# Altium schematic sheet Backplane-Connector.SchDoc(Backplane-Connector)
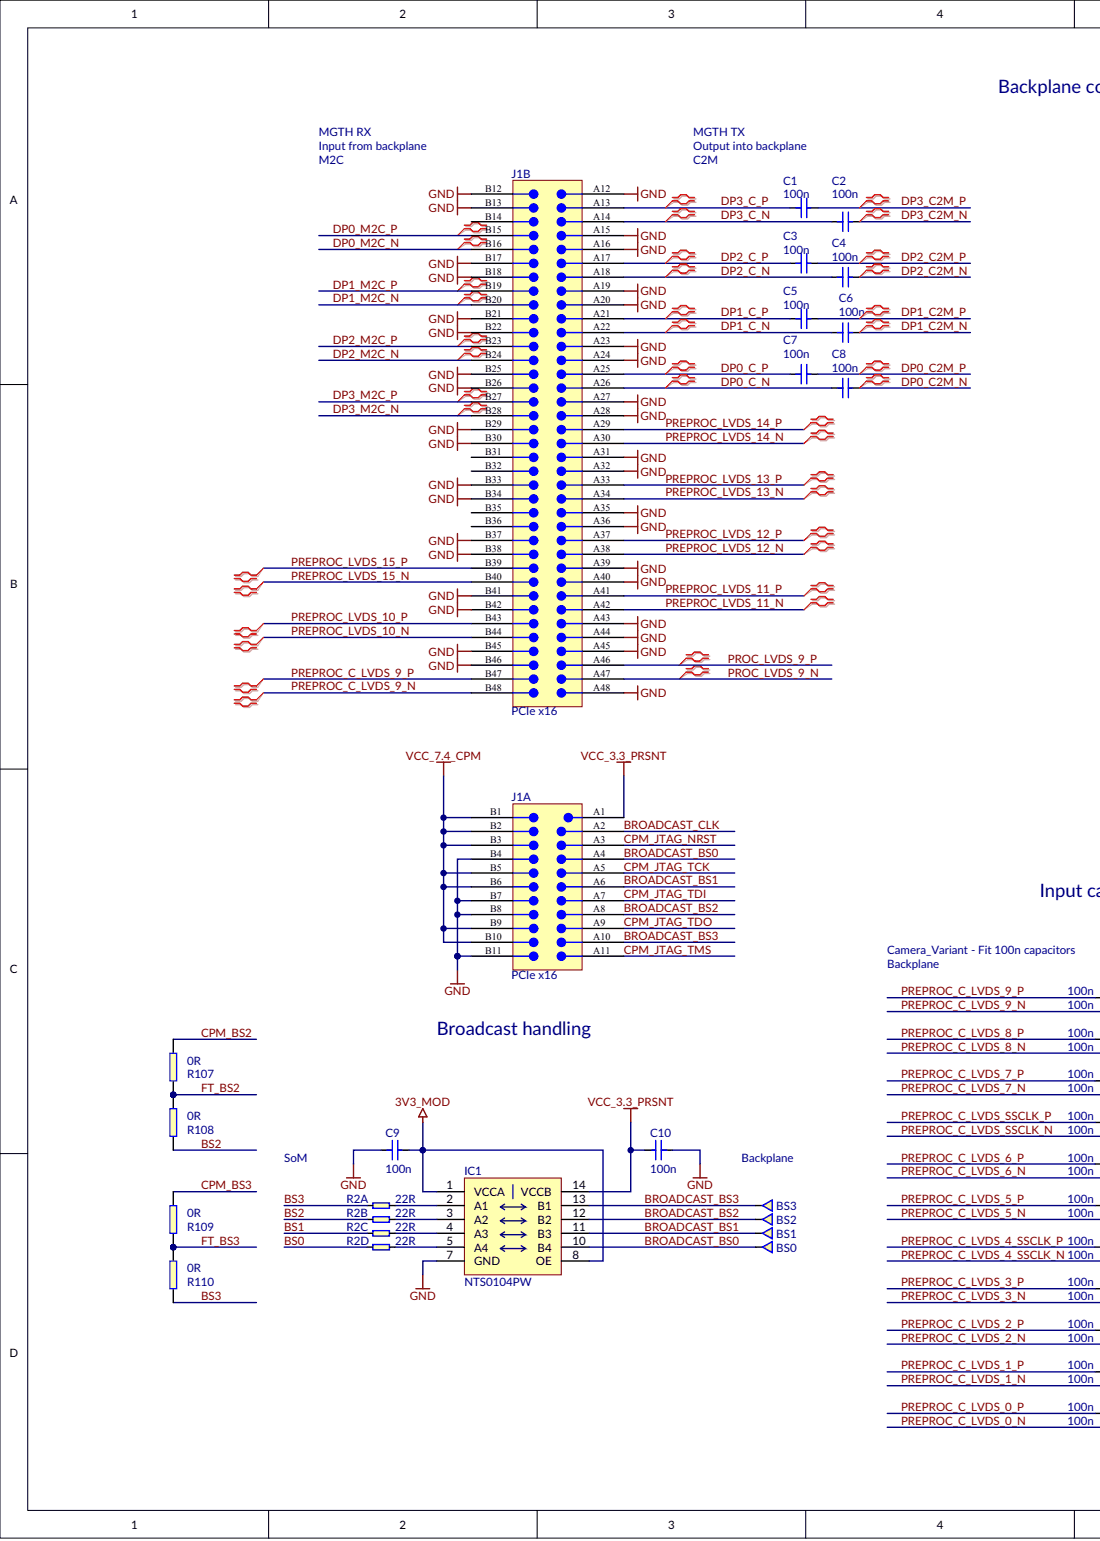
[diagram p0: Backplane-Connector.SchDoc, left]
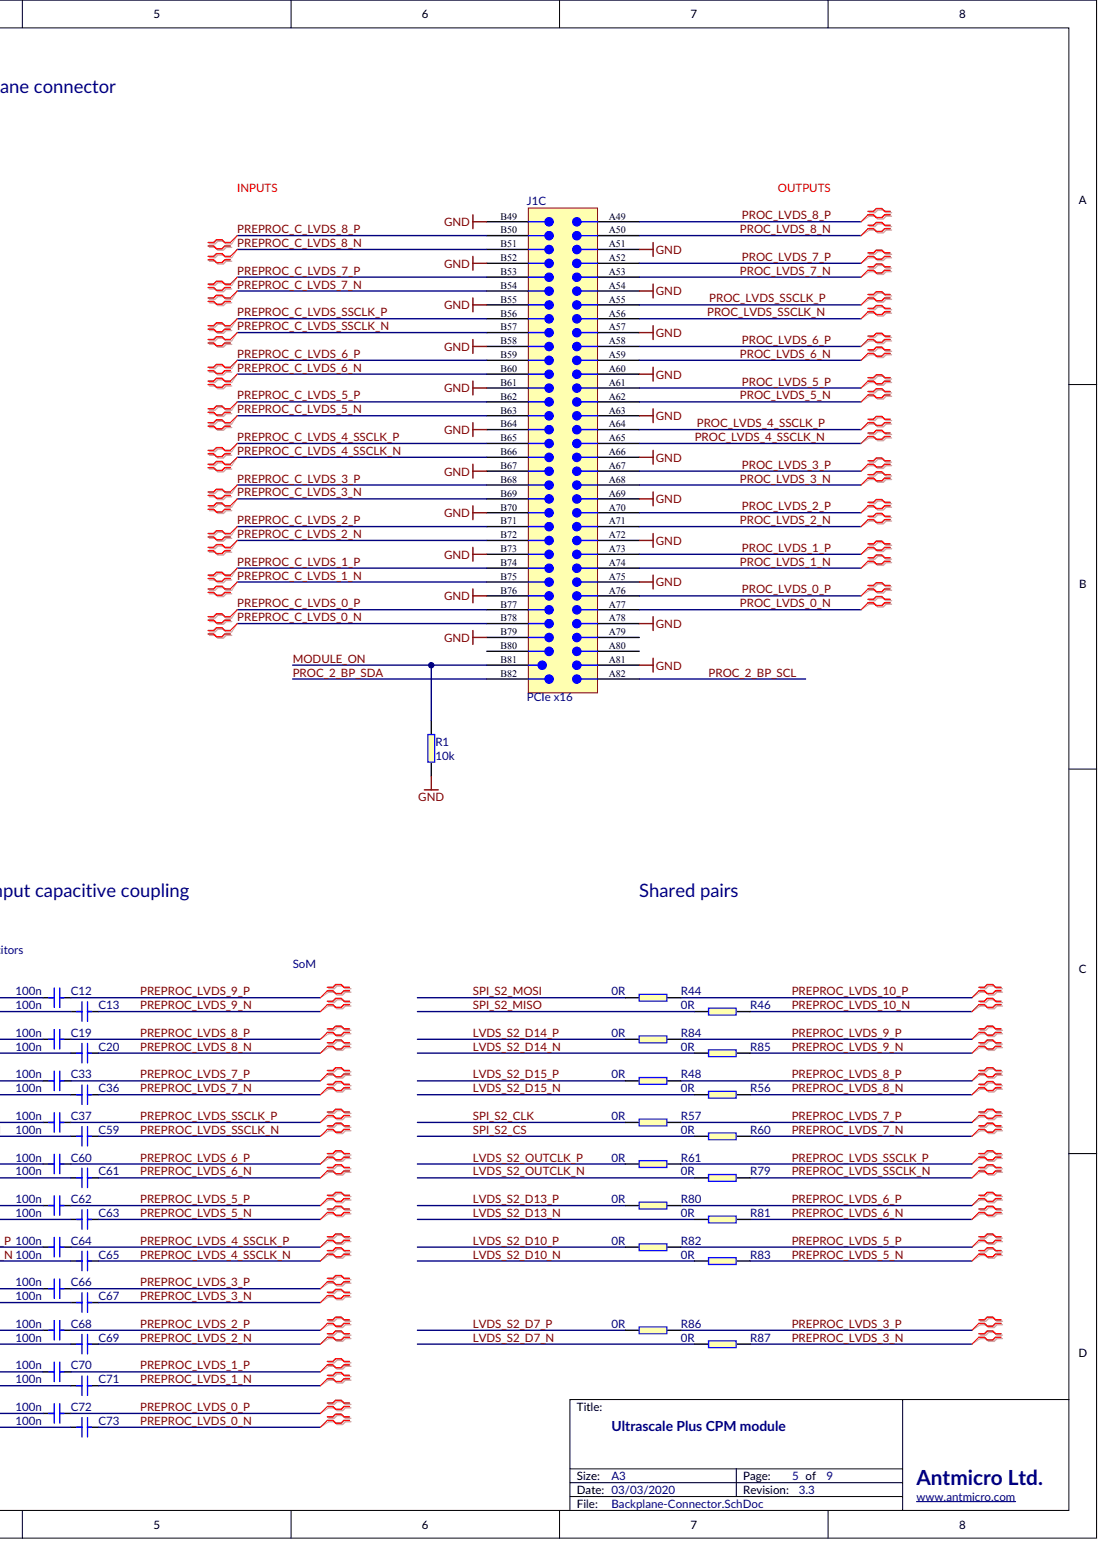
[diagram p1: Backplane-Connector.SchDoc, right]

## Parts
BS0  *  (value not drawn)
BS1  *  (value not drawn)
BS2  *  (value not drawn)
BS3  *  (value not drawn)
C1  100n
C2  100n
C3  100n
C4  100n
C5  100n
C6  100n
C7  100n
C8  100n
C9  100n
C10  100n
C12  100n
C13  100n
C19  100n
C20  100n
C33  100n
C36  100n
C37  100n
C59  100n
C60  100n
C61  100n
C62  100n
C63  100n
C64  100n
C65  100n
C66  100n
C67  100n
C68  100n
C69  100n
C70  100n
C71  100n
C72  100n
C73  100n
IC1  NTS0104PW
J1  PCIe x16  (parts A,B,C)
R1  10k
R2  22R  (parts A,B,C,D)
R44  0R
R46  0R
R48  0R
R56  0R
R57  0R
R60  0R
R61  0R
R79  0R
R80  0R
R81  0R
R82  0R
R83  0R
R84  0R
R85  0R
R86  0R
R87  0R
R107  0R
R108  0R
R109  0R
R110  0R

## Nets
3V3_MOD: C9.2 IC1.1(VCCA) IC1.8(OE)
GND: C9.1 C10.1 IC1.7(GND) J1.A12 J1.A15 J1.A16 J1.A19 J1.A20 J1.A23 J1.A24 J1.A27 J1.A28 J1.A31 J1.A32 J1.A35 J1.A36 J1.A39 J1.A40 J1.A43 J1.A44 J1.A45 J1.A48 J1.A51 J1.A54 J1.A57 J1.A60 J1.A63 J1.A66 J1.A69 J1.A72 J1.A75 J1.A78 J1.A81 J1.B4 J1.B7 J1.B8 J1.B11 J1.B12 J1.B13 J1.B17 J1.B18 J1.B21 J1.B22 J1.B25 J1.B26 J1.B29 J1.B30 J1.B33 J1.B34 J1.B37 J1.B38 J1.B41 J1.B42 J1.B45 J1.B46 J1.B49 J1.B52 J1.B55 J1.B58 J1.B61 J1.B64 J1.B67 J1.B70 J1.B73 J1.B76 J1.B79 R1.1
VCC_3.3_PRSNT: C10.2 IC1.14(VCCB) J1.A1
VCC_7.4_CPM: J1.B1 J1.B2 J1.B3 J1.B5 J1.B6 J1.B9 J1.B10
BROADCAST_BS0: BS0.1 IC1.10(B4) J1.A4
BROADCAST_BS1: BS1.1 IC1.11(B3) J1.A6
BROADCAST_BS2: BS2.1 IC1.12(B2) J1.A8
BROADCAST_BS3: BS3.1 IC1.13(B1) J1.A10
BROADCAST_CLK: J1.A2
BS0: R2.7
BS1: R2.5
BS2: R2.3 R108.1
BS3: R2.1 R110.1
CPM_BS2: R107.2
CPM_BS3: R109.2
CPM_JTAG_NRST: J1.A3
CPM_JTAG_TCK: J1.A5
CPM_JTAG_TDI: J1.A7
CPM_JTAG_TDO: J1.A9
CPM_JTAG_TMS: J1.A11
DP0_C2M_N: C8.2
DP0_C2M_P: C7.2
DP0_C_N: C8.1 J1.A26
DP0_C_P: C7.1 J1.A25
DP0_M2C_N: J1.B16
DP0_M2C_P: J1.B15
DP1_C2M_N: C6.2
DP1_C2M_P: C5.2
DP1_C_N: C6.1 J1.A22
DP1_C_P: C5.1 J1.A21
DP1_M2C_N: J1.B20
DP1_M2C_P: J1.B19
DP2_C2M_N: C4.2
DP2_C2M_P: C3.2
DP2_C_N: C4.1 J1.A18
DP2_C_P: C3.1 J1.A17
DP2_M2C_N: J1.B24
DP2_M2C_P: J1.B23
DP3_C2M_N: C2.2
DP3_C2M_P: C1.2
DP3_C_N: C2.1 J1.A14
DP3_C_P: C1.1 J1.A13
DP3_M2C_N: J1.B28
DP3_M2C_P: J1.B27
FT_BS2: R107.1 R108.2
FT_BS3: R109.1 R110.2
LVDS_S2_D7_N: R87.1
LVDS_S2_D7_P: R86.1
LVDS_S2_D10_N: R83.1
LVDS_S2_D10_P: R82.1
LVDS_S2_D13_N: R81.1
LVDS_S2_D13_P: R80.1
LVDS_S2_D14_N: R85.1
LVDS_S2_D14_P: R84.1
LVDS_S2_D15_N: R56.1
LVDS_S2_D15_P: R48.1
LVDS_S2_OUTCLK_N: R79.1
LVDS_S2_OUTCLK_P: R61.1
MODULE_ON: J1.B81 R1.2
PREPROC_C_LVDS_0_N: C73.1 J1.B78
PREPROC_C_LVDS_0_P: C72.1 J1.B77
PREPROC_C_LVDS_1_N: C71.1 J1.B75
PREPROC_C_LVDS_1_P: C70.1 J1.B74
PREPROC_C_LVDS_2_N: C69.1 J1.B72
PREPROC_C_LVDS_2_P: C68.1 J1.B71
PREPROC_C_LVDS_3_N: C67.1 J1.B69
PREPROC_C_LVDS_3_P: C66.1 J1.B68
PREPROC_C_LVDS_4_SSCLK_N: C65.1 J1.B66
PREPROC_C_LVDS_4_SSCLK_P: C64.1 J1.B65
PREPROC_C_LVDS_5_N: C63.1 J1.B63
PREPROC_C_LVDS_5_P: C62.1 J1.B62
PREPROC_C_LVDS_6_N: C61.1 J1.B60
PREPROC_C_LVDS_6_P: C60.1 J1.B59
PREPROC_C_LVDS_7_N: C36.1 J1.B54
PREPROC_C_LVDS_7_P: C33.1 J1.B53
PREPROC_C_LVDS_8_N: C20.1 J1.B51
PREPROC_C_LVDS_8_P: C19.1 J1.B50
PREPROC_C_LVDS_9_N: C13.1 J1.B48
PREPROC_C_LVDS_9_P: C12.1 J1.B47
PREPROC_C_LVDS_SSCLK_N: C59.1 J1.B57
PREPROC_C_LVDS_SSCLK_P: C37.1 J1.B56
PREPROC_LVDS_0_N: C73.2
PREPROC_LVDS_0_P: C72.2
PREPROC_LVDS_1_N: C71.2
PREPROC_LVDS_1_P: C70.2
PREPROC_LVDS_2_N: C69.2
PREPROC_LVDS_2_P: C68.2
PREPROC_LVDS_3_N: C67.2 R87.2
PREPROC_LVDS_3_P: C66.2 R86.2
PREPROC_LVDS_4_SSCLK_N: C65.2
PREPROC_LVDS_4_SSCLK_P: C64.2
PREPROC_LVDS_5_N: C63.2 R83.2
PREPROC_LVDS_5_P: C62.2 R82.2
PREPROC_LVDS_6_N: C61.2 R81.2
PREPROC_LVDS_6_P: C60.2 R80.2
PREPROC_LVDS_7_N: C36.2 R60.2
PREPROC_LVDS_7_P: C33.2 R57.2
PREPROC_LVDS_8_N: C20.2 R56.2
PREPROC_LVDS_8_P: C19.2 R48.2
PREPROC_LVDS_9_N: C13.2 R85.2
PREPROC_LVDS_9_P: C12.2 R84.2
PREPROC_LVDS_10_N: J1.B44 R46.2
PREPROC_LVDS_10_P: J1.B43 R44.2
PREPROC_LVDS_11_N: J1.A42
PREPROC_LVDS_11_P: J1.A41
PREPROC_LVDS_12_N: J1.A38
PREPROC_LVDS_12_P: J1.A37
PREPROC_LVDS_13_N: J1.A34
PREPROC_LVDS_13_P: J1.A33
PREPROC_LVDS_14_N: J1.A30
PREPROC_LVDS_14_P: J1.A29
PREPROC_LVDS_15_N: J1.B40
PREPROC_LVDS_15_P: J1.B39
PREPROC_LVDS_SSCLK_N: C59.2 R79.2
PREPROC_LVDS_SSCLK_P: C37.2 R61.2
PROC_2_BP_SCL: J1.A82
PROC_2_BP_SDA: J1.B82
PROC_LVDS_0_N: J1.A77
PROC_LVDS_0_P: J1.A76
PROC_LVDS_1_N: J1.A74
PROC_LVDS_1_P: J1.A73
PROC_LVDS_2_N: J1.A71
PROC_LVDS_2_P: J1.A70
PROC_LVDS_3_N: J1.A68
PROC_LVDS_3_P: J1.A67
PROC_LVDS_4_SSCLK_N: J1.A65
PROC_LVDS_4_SSCLK_P: J1.A64
PROC_LVDS_5_N: J1.A62
PROC_LVDS_5_P: J1.A61
PROC_LVDS_6_N: J1.A59
PROC_LVDS_6_P: J1.A58
PROC_LVDS_7_N: J1.A53
PROC_LVDS_7_P: J1.A52
PROC_LVDS_8_N: J1.A50
PROC_LVDS_8_P: J1.A49
PROC_LVDS_9_N: J1.A47
PROC_LVDS_9_P: J1.A46
PROC_LVDS_SSCLK_N: J1.A56
PROC_LVDS_SSCLK_P: J1.A55
SPI_S2_CLK: R57.1
SPI_S2_CS: R60.1
SPI_S2_MISO: R46.1
SPI_S2_MOSI: R44.1
NetIC1_2: IC1.2(A1) R2.2
NetIC1_3: IC1.3(A2) R2.4
NetIC1_4: IC1.4(A3) R2.6
NetIC1_5: IC1.5(A4) R2.8

Not connected: J1 A79 A80 B14 B31 B32 B35 B36 B80

## Library data of the parts
BS0-BS3 = (no symbol name): Part Number: Testpad
C1-C10 C12 C13 C19 C20 C33 C36 C37 C59-C73 = (no symbol name): Part Number: C_100n_0402; Manufacturer: MULTICOMP; Part Symbol: MC0402X104M100CT; Value: 100n; Supplier 1: Farnell; Supplier Part Number 1: 2309011
IC1 = (no symbol name): Manufacturer: NXP; Part Number: NTS0104PW; Value: NTS0104PW; Supplier 1: Farnell; Supplier Part Number 1: 2164815; Supplier 2: Mouser; Supplier Part Number 2: 771-NTS0104PW118; Part Symbol: NTS0104PW
J1 = (no symbol name): Manufacturer Part Number 1: 10018783-10113TLF; Current Rating: 1.1 A; Populated: Yes; Proven: No; Category: PCI Express / PCI Connectors; Review: Yes
R1 = (no symbol name): Part Number: R_10k_0603; Manufacturer: BOURNS; Part Symbol: CR0603-JW-103ELF; Value: 10k; Supplier 1: Farnell; Supplier Part Number 1: 2008360
R2 = (no symbol name): Part Number: CAY16-220J4LF; Manufacturer: BOURNS; Value: 22R; Supplier 1: Farnell; Supplier Part Number 1: 1770127; Part Symbol: CAY16-220J4LF
R44 R46 R48 R56 R57 R60 R61 R79-R87 = (no symbol name): Part Number: R_0R_0402; Manufacturer: MULTICOMP; Part Symbol: MCMR04X000 PTL; Value: 0R; Supplier 1: Farnell; Supplier Part Number 1: 2072513
R107-R110 = (no symbol name): PartNumber: R_0R_0402; Manufacturer: MULTICOMP; Value: 0R; Supplier1: Farnell; SupplierPartNumber1: 2072513
